# Altium schematic sheet Interface.SchDoc(Interface)
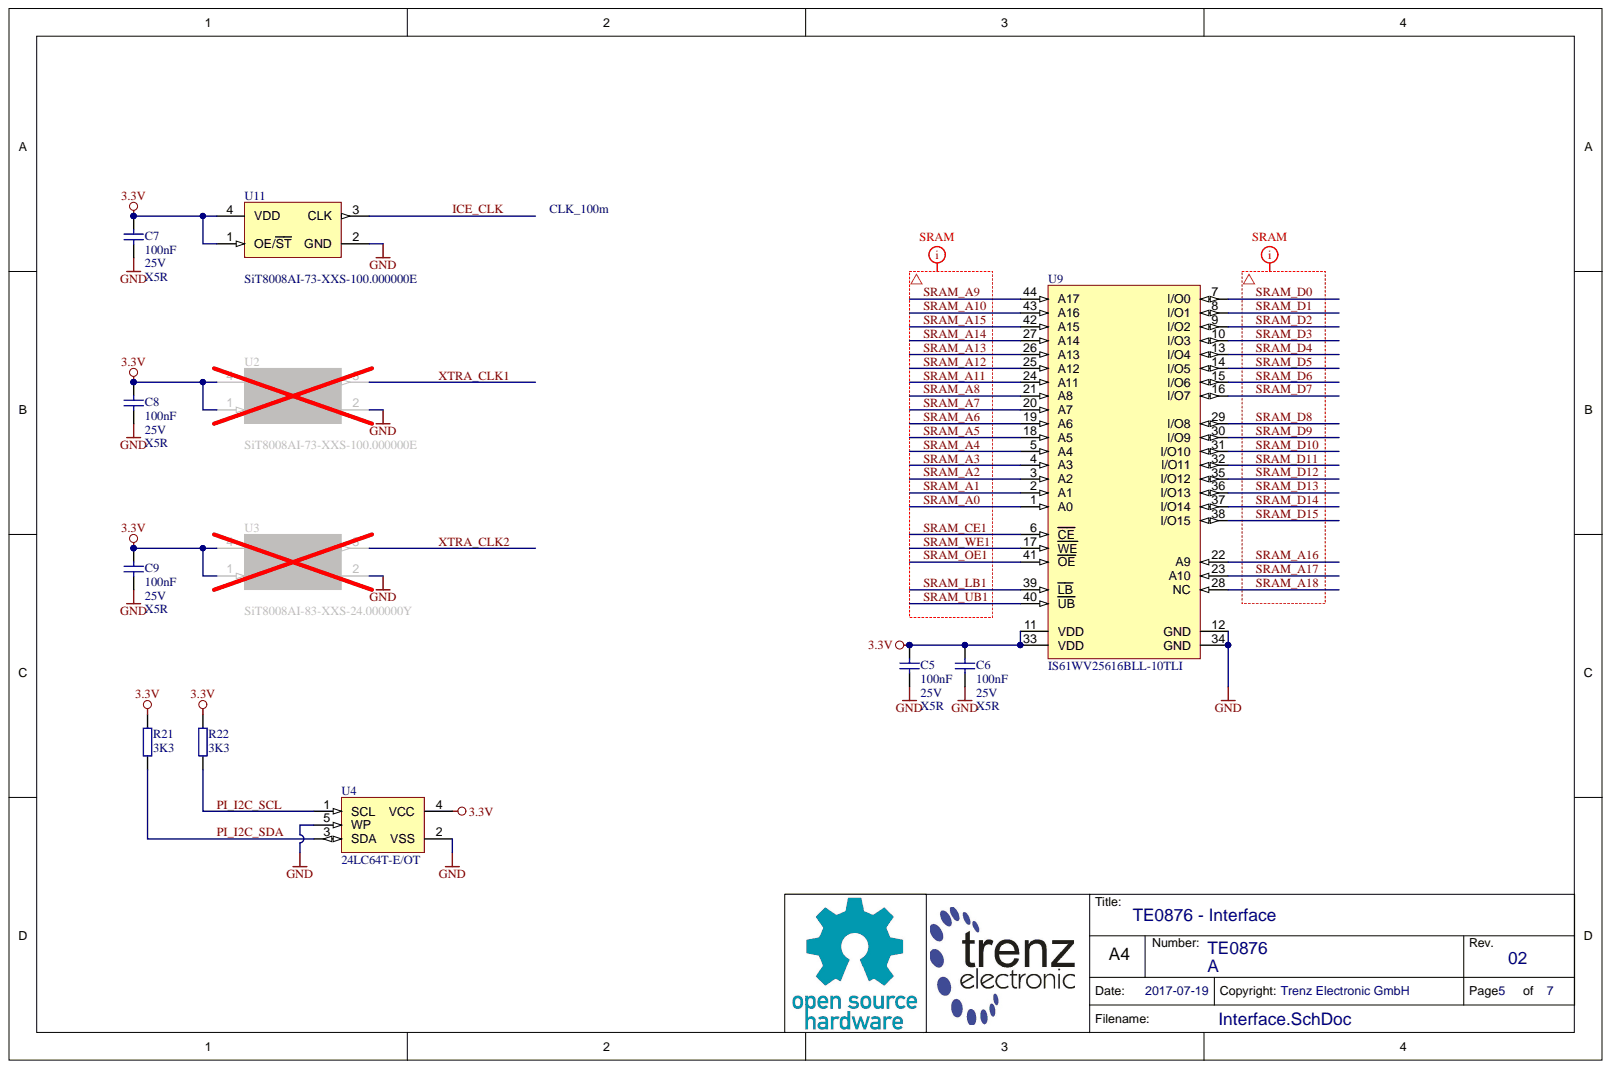
[diagram p0: Interface.SchDoc]

## Parts
C5  100nF  Voltage: 25V  Technology: X5R  [CAPC1005X55N]
C6  100nF  Voltage: 25V  Technology: X5R  [CAPC1005X55N]
C7  100nF  Voltage: 25V  Technology: X5R  [CAPC1005X55N]
C8  100nF  Voltage: 25V  Technology: X5R  [CAPC1005X55N]
C9  100nF  Voltage: 25V  Technology: X5R  [CAPC1005X55N]
R21  3K3  [RESC1005X40N]
R22  3K3  [RESC1005X40N]
U2  SiT8008AI-73-XXS-100.000000E  Database Table Name: CLK  [2016]
U3  SiT8008AI-83-XXS-24.000000Y  Database Table Name: CLK  [DFN700X500X100-4N]
U4  24LC64T-E/OT  [SOT95P280X145-5N]
U9  IS61WV25616BLL-10TLI  [SOP80P1176X120-44L29]
U11  SiT8008AI-73-XXS-100.000000E  Database Table Name: CLK  [2016]

## Nets
3.3V: C5.1 C6.1 C7.1 C8.1 C9.1 R21.2 R22.2 U2.1(OE/ST) U2.4(VDD) U3.1(OE/ST) U3.4(VDD) U4.4(VCC) U9.11(VDD) U9.33(VDD) U11.1(OE/ST) U11.4(VDD)
GND: C5.2 C6.2 C7.2 C8.2 C9.2 U2.2(GND) U3.2(GND) U4.2(VSS) U4.5(WP) U9.12(GND) U9.34(GND) U11.2(GND)
ICE_CLK: U11.3(CLK)
PI_I2C_SCL: R22.1 U4.1(SCL)
PI_I2C_SDA: R21.1 U4.3(SDA)
SRAM_A0: U9.1(A0)
SRAM_A1: U9.2(A1)
SRAM_A2: U9.3(A2)
SRAM_A3: U9.4(A3)
SRAM_A4: U9.5(A4)
SRAM_A5: U9.18(A5)
SRAM_A6: U9.19(A6)
SRAM_A7: U9.20(A7)
SRAM_A8: U9.21(A8)
SRAM_A9: U9.44(A17)
SRAM_A10: U9.43(A16)
SRAM_A11: U9.24(A11)
SRAM_A12: U9.25(A12)
SRAM_A13: U9.26(A13)
SRAM_A14: U9.27(A14)
SRAM_A15: U9.42(A15)
SRAM_A16: U9.22(A9)
SRAM_A17: U9.23(A10)
SRAM_A18: U9.28(NC)
SRAM_CE1: U9.6(CE)
SRAM_D0: U9.7(I/O0)
SRAM_D1: U9.8(I/O1)
SRAM_D2: U9.9(I/O2)
SRAM_D3: U9.10(I/O3)
SRAM_D4: U9.13(I/O4)
SRAM_D5: U9.14(I/O5)
SRAM_D6: U9.15(I/O6)
SRAM_D7: U9.16(I/O7)
SRAM_D8: U9.29(I/O8)
SRAM_D9: U9.30(I/O9)
SRAM_D10: U9.31(I/O10)
SRAM_D11: U9.32(I/O11)
SRAM_D12: U9.35(I/O12)
SRAM_D13: U9.36(I/O13)
SRAM_D14: U9.37(I/O14)
SRAM_D15: U9.38(I/O15)
SRAM_LB1: U9.39(LB)
SRAM_OE1: U9.41(OE)
SRAM_UB1: U9.40(UB)
SRAM_WE1: U9.17(WE)
XTRA_CLK1: U2.3(CLK)
XTRA_CLK2: U3.3(CLK)
N00164:
N00165:

## Library data of the parts
C5-C9 = 22472: KON 100nF 25V X5R SMD ±10%; Value: 100n; Preferred: Yes; Mixed: SMT; Database Table Name: C_1005_0402; Library Name: TE.DbLib; Component Kind: Standard; Case: 1005 (0402); AN: 22472
R21 R22 = 22763: WID SMD 3K3 1% 0,063W; Value: 3.3K; Preferred: Yes; Mixed: SMT; Database Table Name: R_1005_0402; Tolerance: 1%; Technology: TK100; Library Name: TE.DbLib; Component Kind: Standard; Case: 1005 (0402); AN: 22763
U2 U11 = 25961: SiT8008AI-73-XXS-100.000000E MEMS Oszillator 100MHz; Value: 100M; Trenz-Stock: Yes; Mixed: SMT; Preferred: Yes; Library Name: TE.DbLib; H-ART: SiT8008AI-73-XXS-100.000000E; Component Kind: Standard; Case: 2.0  x 1.6mm; AN: 25961; HelpURL: B:\AD\adlib\datasheet\SiT8008
U3 = 25159: SiT8008AI-83-XXS-24.000000Y MEMS Oszillator 24MHz; Value: 24M; Trenz-Stock: Yes; Mixed: SMT; Preferred: Yes; Library Name: TE.DbLib; H-ART: SiT8008AI-83-XXS-24.000000Y; Component Kind: Standard; Case: 7.0 x 5.0 mm; AN: 25159; HelpURL: B:\AD\adlib\datasheet\SiT8008
U4 = 26684: Trenz-Stock: Yes; Mixed: SMT; Database Table Name: A_EEPROM; Library Name: TE.DbLib; H-ART: 24LC64T-E/OT; Description: 24LC64T-E/OT; Component Kind: Standard; Case: SOT23-5; AN: 26684; HelpURL: B:\ad\adlib\datasheet\24LC02BHT
U9 = 28537: Mixed: SMT; Database Table Name: SRAM; Library Name: TE.DbLib; H-ART: IS61WV25616BLL-10TLI; Description: IS61WV25616BLL-10TLI; Component Kind: Standard; Case: TSOP2-44; AN: 28537; HelpURL: b:\AD\adlib\datasheet\IS6xWV25616xLx\
